# Altium schematic sheet µController.SchDoc
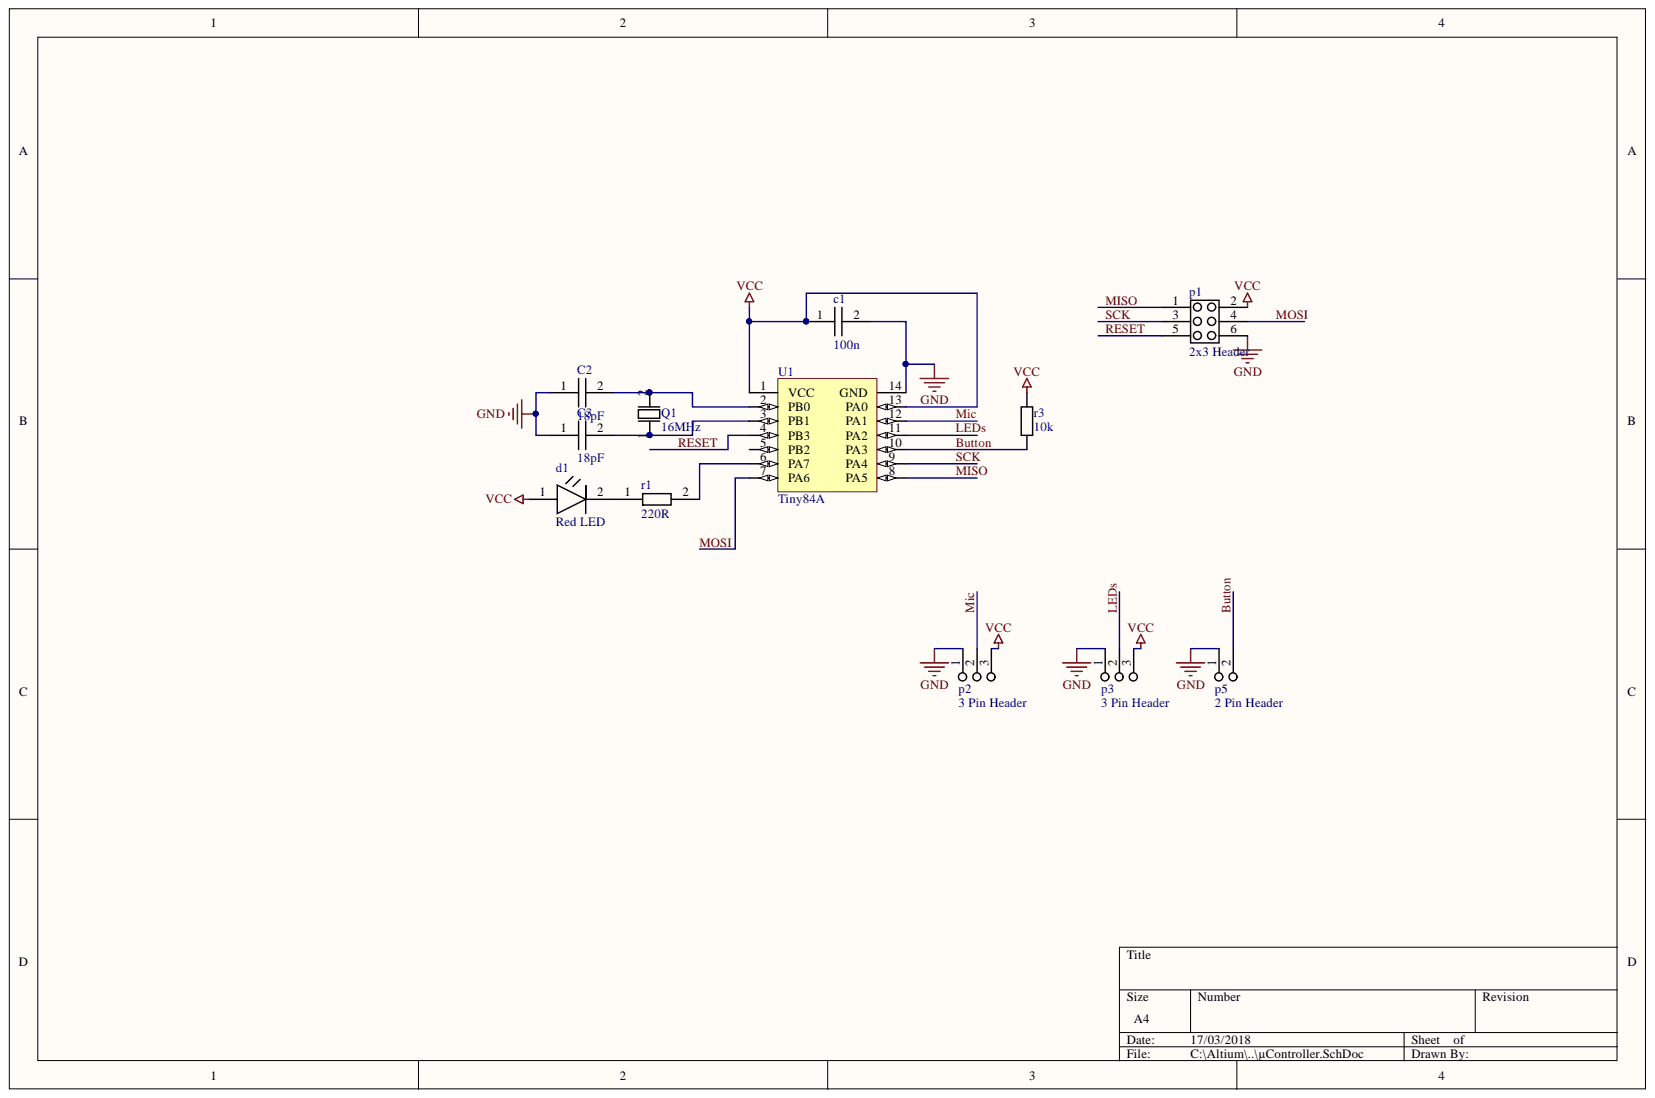
[diagram p0: µController.SchDoc]

## Parts
c1  100n  [0805]
C2  18pF  [0603]
C3  18pF  [0603]
d1  Red LED  [0805 - diode]
p1  2x3 Header  [2x3-HeaderPin]
p2  3 Pin Header  [1x3-HeaderPin]
p3  3 Pin Header  [1x3-HeaderPin]
p5  2 Pin Header  [1x2-HeaderPin]
Q1  16MHz  [Crystal 488P]
r1  220R  [0805]
r3  10k  [0603]
U1  Tiny84A  [SOIC14N]

## Nets
GND: c1.2 C2.1 C3.1 p1.6 p2.1 p3.1 p5.1 U1.14(GND)
VCC: c1.1 d1.1 p1.2 p2.3 p3.3 r3.2 U1.1(VCC) U1.13(PA0)
Button: p5.2 r3.1 U1.10(PA3)
LEDs: p3.2 U1.11(PA2)
Mic: p2.2 U1.12(PA1)
MISO: p1.1 U1.8(PA5)
MOSI: p1.4 U1.7(PA6)
RESET: p1.5 U1.4(PB3)
SCK: p1.3 U1.9(PA4)
NetC2_2: C2.2 Q1.2 U1.2(PB0)
NetC3_2: C3.2 Q1.1 U1.3(PB1)
Netd1_2: d1.2 r1.1
Netr1_2: r1.2 U1.6(PA7)

Not connected: U1 5(PB2)

## Library data of the parts
c1 = 0805 Capacitor: ...F; Component Kind: Standard; Library Name: Generic R-C.SchLib
C2 C3 = 0603 Capacitor: ...F; Component Kind: Standard; Library Name: Generic R-C.SchLib
d1 = Red LED: Red diffused LED; Component Kind: Standard; Library Name: Broadcom.SchLib
p1 = 2x3 Header: Header Pin; Component Kind: Standard; Library Name: Multicomp.SchLib
p2 p3 = 3 Pin Header: Header Pin; Component Kind: Standard; Library Name: Multicomp.SchLib
Q1 = Crystal: ...MHz; Component Kind: Standard; Library Name: FOX Crystals.SchLib
r1 = 0805 Resistor: ...R; Component Kind: Standard; Library Name: Generic R-C.SchLib
r3 = 0603 Resistor: ...R; Component Kind: Standard; Library Name: Generic R-C.SchLib
U1 = ATTiny84A: Component Kind: Standard; Description: ATTiny84A; Library Name: Microchip-Atmel.SchLib
p5 = 2 Pin Header: Component Kind: Standard; Description: 2 Pin Header; Library Name: Multicomp.SchLib
